# Altium schematic sheet Power.SchDoc(Power)
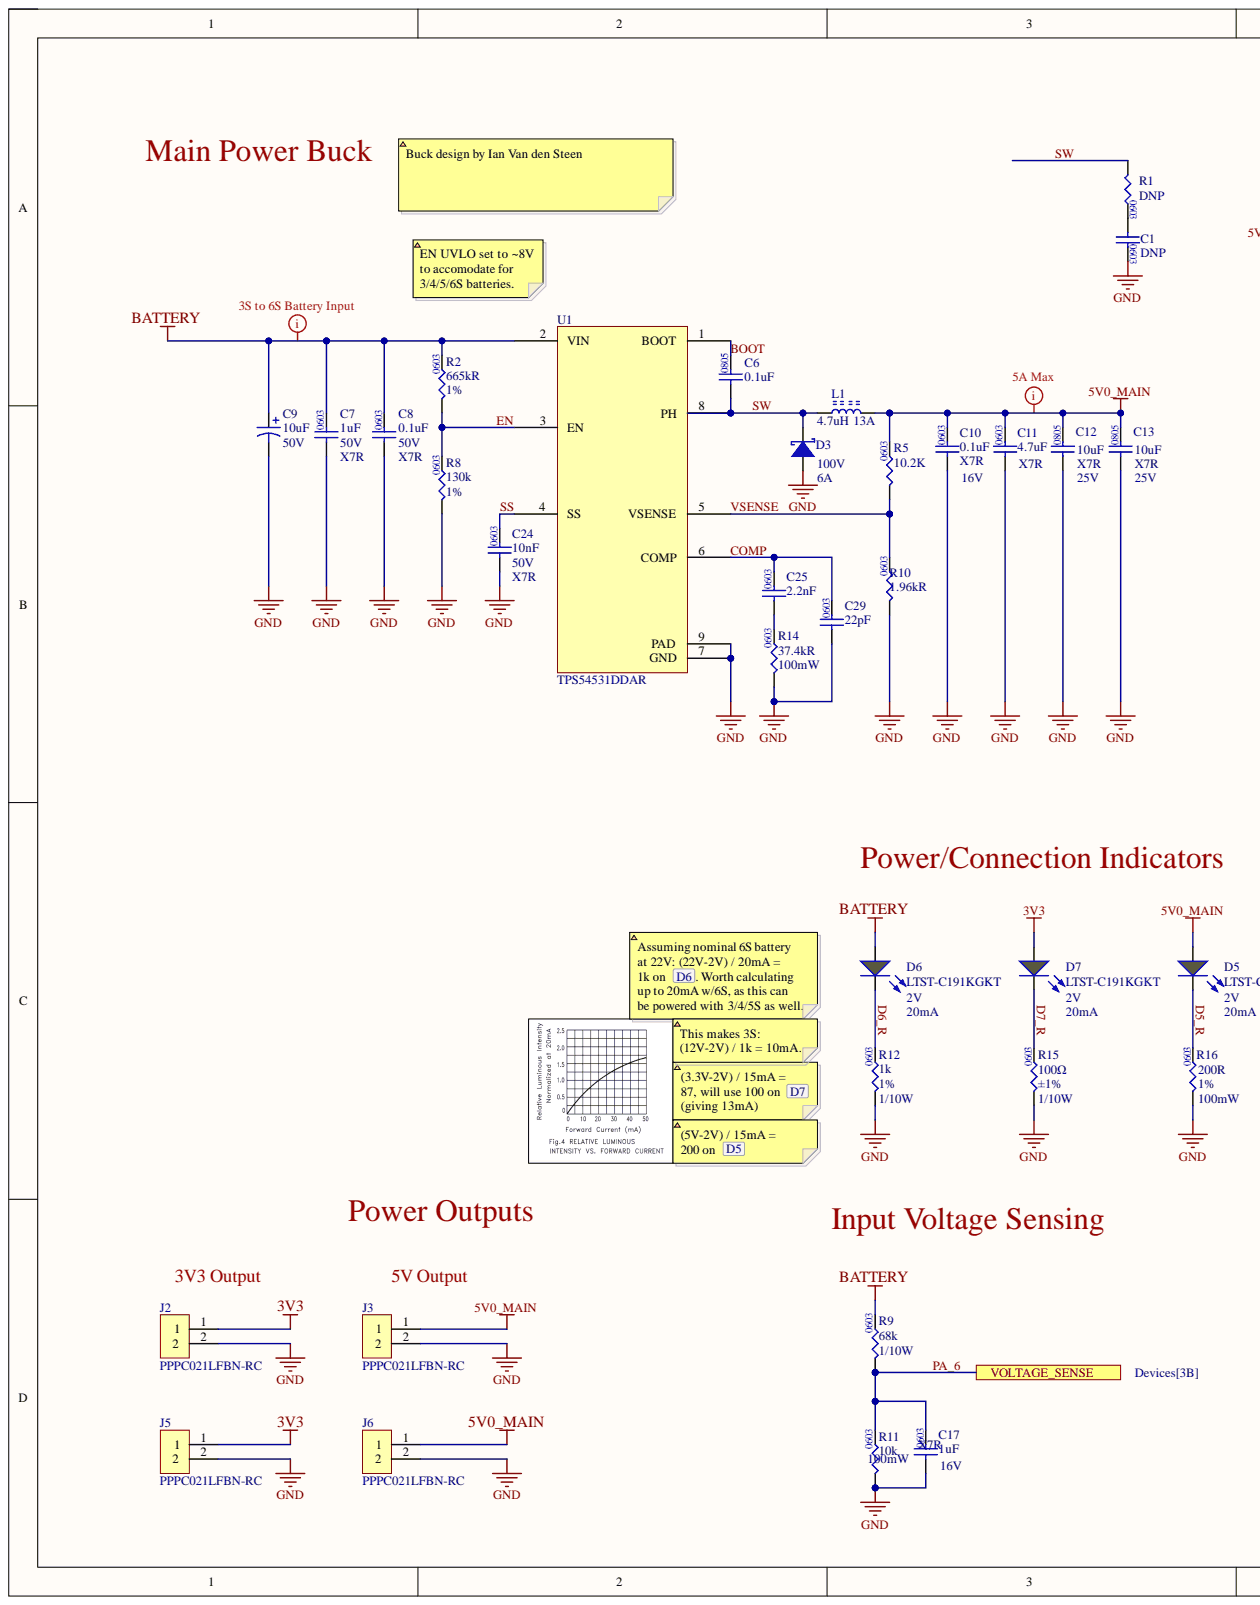
[diagram p0: Power.SchDoc, left]
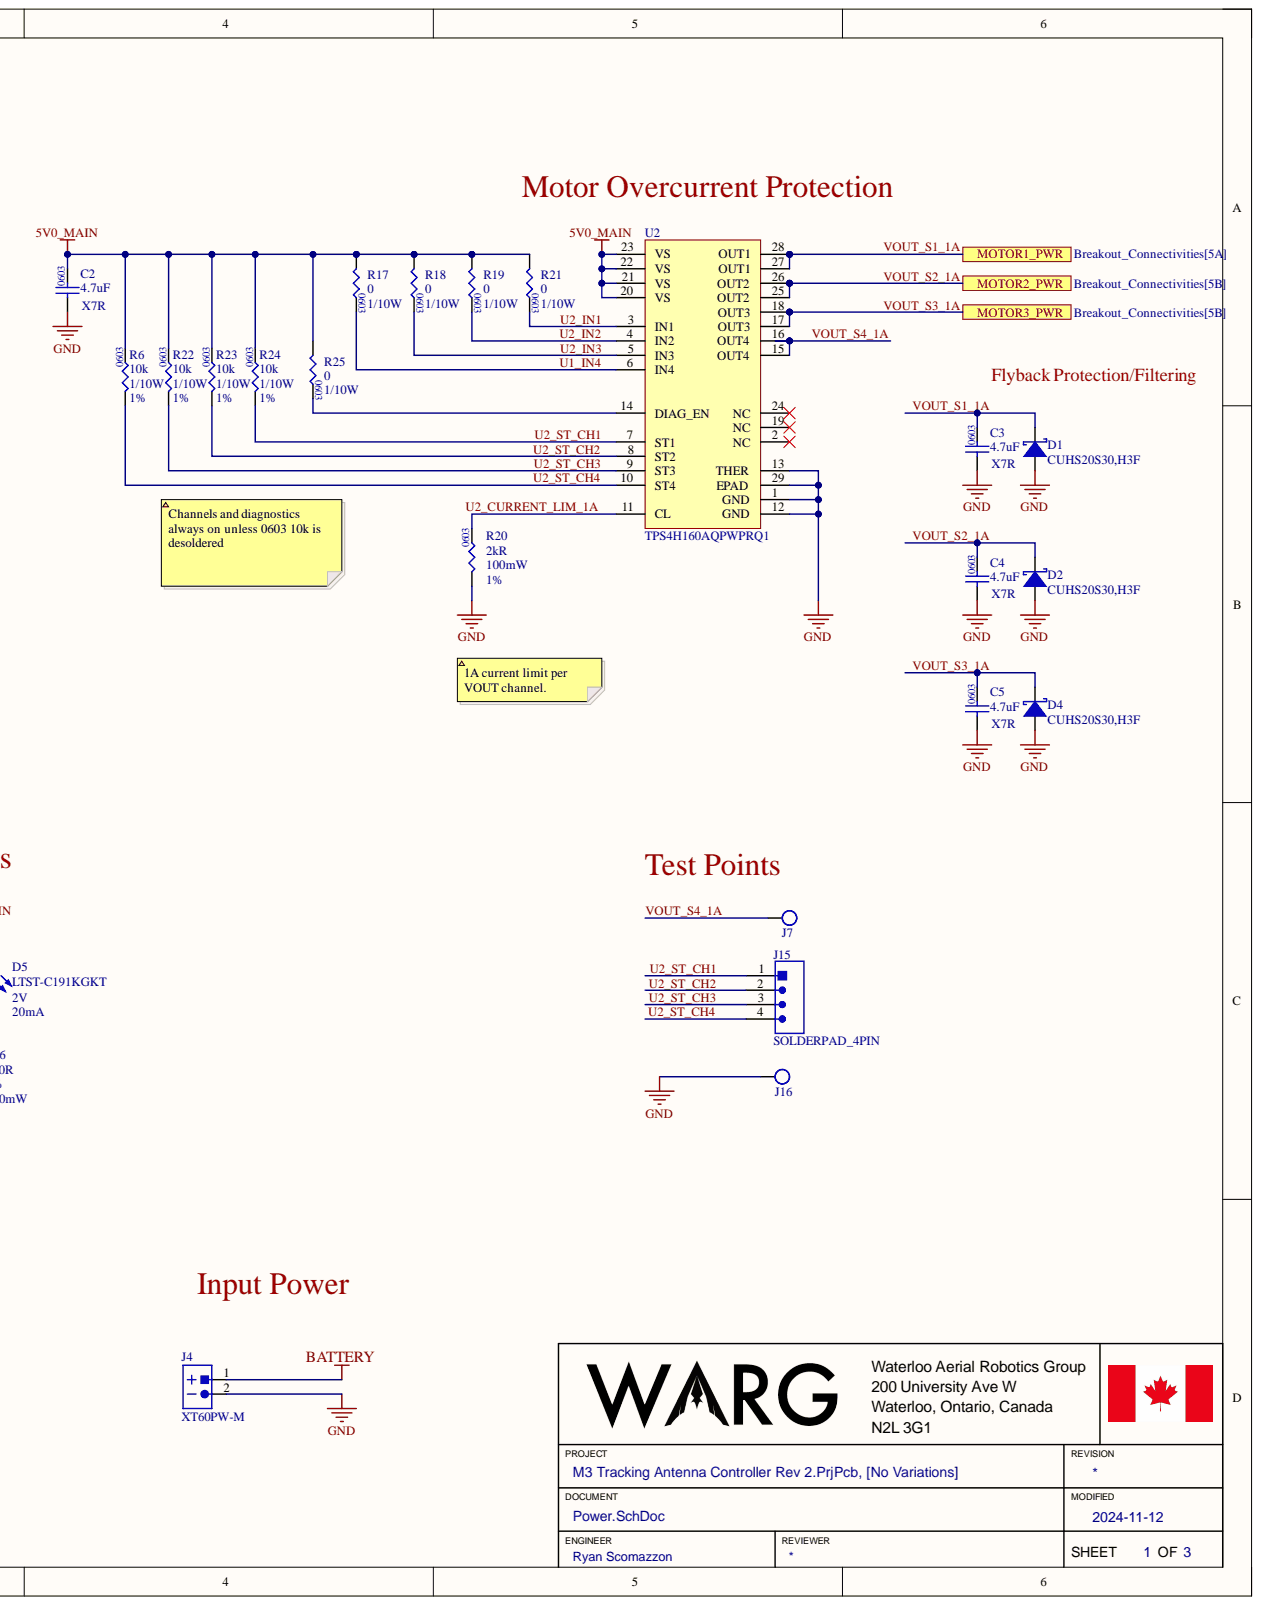
[diagram p1: Power.SchDoc, right]

## Parts
C1  value DNP  [.CAP_0603-Footprint-1]  (hidden comment: .CAP_0603_DNP)
C2  value 4.7uF  Case/Package: 0603  Temperature Characteristics Code: X7R  [.CAP_0603-Footprint-1]  (hidden comment: GRM188Z71C475KE21J)
C3  value 4.7uF  Case/Package: 0603  Temperature Characteristics Code: X7R  [.CAP_0603-Footprint-1]  (hidden comment: GRM188Z71C475KE21J)
C4  value 4.7uF  Case/Package: 0603  Temperature Characteristics Code: X7R  [.CAP_0603-Footprint-1]  (hidden comment: GRM188Z71C475KE21J)
C5  value 4.7uF  Case/Package: 0603  Temperature Characteristics Code: X7R  [.CAP_0603-Footprint-1]  (hidden comment: GRM188Z71C475KE21J)
C6  value 0.1uF  Case/Package: 0805  [.CAP_0805-Footprint-1]  (hidden comment: GCE21BR71H104KA01L)
C7  Capacitance: 1uF  Package Size: 0603  Temperature Coefficient: X7R  Voltage Rating: 50V  [.CAP_0603-Footprint-1]  (hidden comment: GMC10X7R105K50NT)
C8  value 0.1uF  Case Code (Imperial): 0603  Dielectric: X7R  Voltage: 50V  [.CAP_0603-Footprint-1]  (hidden comment: GCM188R71H104KA57D)
C9  value 10uF  Capacitance: 10uF  Voltage: 50V  [865080642006-Footprint-1]  (hidden comment: 865080642006)
C10  value 0.1uF  Package: 0603  Temperature Coefficient: X7R  Voltage: 16V  [.CAP_0603-Footprint-1]  (hidden comment: GCM188R71C104KA37D)
C11  value 4.7uF  Case/Package: 0603  Temperature Characteristics Code: X7R  [.CAP_0603-Footprint-1]  (hidden comment: GRM188Z71C475KE21J)
C12  Capacitance: 10uF  Temperature Coefficient: X7R  Voltage Rating: 25V  [.CAP_0805-Footprint-1]  (hidden comment: GMC21X7R106K25NT)
C13  Capacitance: 10uF  Temperature Coefficient: X7R  Voltage Rating: 25V  [.CAP_0805-Footprint-1]  (hidden comment: GMC21X7R106K25NT)
C17  value 1uF  Capacitance: 1uF  Package: 0603  Temperature Coefficient: X7R  Voltage: 16V  [.CAP_0603-Footprint-1]  (hidden comment: GCM188R71C105KA64J)
C24  Capacitance: 10nF  Dielectric: X7R  Package: 0603  Voltage: 50V  [.CAP_0603-Footprint-1]  (hidden comment: GCM188R71H103KA37D)
C25  value 2.2nF  Case/Package: 0603  [.CAP_0603-Footprint-1]  (hidden comment: GRM1885C1H222JA01D)
C29  value 22pF  Package: 0603  [.CAP_0603-Footprint-1]  (hidden comment: CC0603JRNPO9BN220)
D1  CUHS20S30,H3F  [CUHS20S30,H3F-Footprint-1]
D2  CUHS20S30,H3F  [CUHS20S30,H3F-Footprint-1]
D3  Current - Average Rectified (Io): 6A  Voltage - DC Reverse (Vr) (Max): 100V  [PMEG100V060ELPEZ-Footprint-1]  (hidden comment: PMEG100V060ELPEZ)
D4  CUHS20S30,H3F  [CUHS20S30,H3F-Footprint-1]
D5  LTST-C191KGKT  Forward Current: 20mA  Forward Voltage: 2V  [.LED_0603-Footprint-1]
D6  LTST-C191KGKT  Forward Current: 20mA  Forward Voltage: 2V  [.LED_0603-Footprint-1]
D7  LTST-C191KGKT  Forward Current: 20mA  Forward Voltage: 2V  [.LED_0603-Footprint-1]
J2  PPPC021LFBN-RC  [PPPC021LFBN-RC-Footprint-1]
J3  PPPC021LFBN-RC  [PPPC021LFBN-RC-Footprint-1]
J4  XT60PW-M  [XT60PW-M-Footprint-1]
J5  PPPC021LFBN-RC  [PPPC021LFBN-RC-Footprint-1]
J6  PPPC021LFBN-RC  [PPPC021LFBN-RC-Footprint-1]
J7  BLDC_SOLDER_PAD  [BLDC_SOLDER_PAD-Footprint-1]  (value not drawn)
J15  SOLDERPAD_4PIN  [SOLDERPAD_4PIN-Footprint-1]
J16  BLDC_SOLDER_PAD  [BLDC_SOLDER_PAD-Footprint-1]  (value not drawn)
L1  value 4.7uH  Current Rating: 13A  [7443330470-Footprint-1]  (hidden comment: 7443330470)
R1  value DNP  [.RES_0603-Footprint-1]  (hidden comment: .RES_0603_DNP)
R2  value 665kR  Case/Package: 0603  Tolerance: 1%  [.RES_0603-Footprint-1]  (hidden comment: RC0603FR-07665KL)
R5  value 10.2K  [.RES_0603-Footprint-1]  (hidden comment: RC0603FR-0710K2L)
R6  value 10k  Package: 0603  Power: 1/10W  Resistance: 10k  Tolerance: 1%  [.RES_0603-Footprint-1]  (hidden comment: RC0603FR-0710KL)
R8  value 130k  Case/Package: 0603  Tolerance: 1%  [.RES_0603-Footprint-1]  (hidden comment: RC0603FR-07130KL)
R9  value 68k  Package: 0603  Power: 1/10W  Resistance: 68k  [.RES_0603-Footprint-1]  (hidden comment: RC0603FR-0768KL)
R10  value 1.96kR  Case/Package: 0603  [.RES_0603-Footprint-1]  (hidden comment: RC0603FR-071K96L)
R11  Package: 0603  Power: 100mW  Resistance: 10k  [.RES_0603-Footprint-1]  (hidden comment: AC0603FR-1310KL)
R12  value 1k  Package: 0603  Power: 1/10W  Tolerance: 1%  [.RES_0603-Footprint-1]  (hidden comment: RC0603FR-071KL)
R14  value 37.4kR  Case/Package: 0603  Power: 100mW  [.RES_0603-Footprint-1]  (hidden comment: RC0603FR-0737K4L)
R15  Package: 0603  Power: 1/10W  Resistance: 100Ω  Tolerance: ±1%  [.RES_0603-Footprint-1]  (hidden comment: RC0603FR-07100RL)
R16  Case/Package: 0603  Power Rating: 100mW  Resistance: 200R  Tolerance: 1%  [.RES_0603-Footprint-1]  (hidden comment: RC0603FR-07200RL)
R17  value 0  Package: 0603  Power: 1/10W  [.RES_0603-Footprint-1]  (hidden comment: RC0603JR-070RL)
R18  value 0  Package: 0603  Power: 1/10W  [.RES_0603-Footprint-1]  (hidden comment: RC0603JR-070RL)
R19  value 0  Package: 0603  Power: 1/10W  [.RES_0603-Footprint-1]  (hidden comment: RC0603JR-070RL)
R20  value 2kR  Case/Package: 0603  Power: 100mW  Tolerance: 1%  [.RES_0603-Footprint-1]  (hidden comment: RC0603FR-072KL)
R21  value 0  Package: 0603  Power: 1/10W  [.RES_0603-Footprint-1]  (hidden comment: RC0603JR-070RL)
R22  value 10k  Package: 0603  Power: 1/10W  Resistance: 10k  Tolerance: 1%  [.RES_0603-Footprint-1]  (hidden comment: RC0603FR-0710KL)
R23  value 10k  Package: 0603  Power: 1/10W  Resistance: 10k  Tolerance: 1%  [.RES_0603-Footprint-1]  (hidden comment: RC0603FR-0710KL)
R24  value 10k  Package: 0603  Power: 1/10W  Resistance: 10k  Tolerance: 1%  [.RES_0603-Footprint-1]  (hidden comment: RC0603FR-0710KL)
R25  value 0  Package: 0603  Power: 1/10W  [.RES_0603-Footprint-1]  (hidden comment: RC0603JR-070RL)
U1  TPS54531DDAR  [TPS54531DDAR]
U2  TPS4H160AQPWPRQ1  [TPS4H160AQPWPRQ1-Footprint-1]

## Ports
MOTOR1_PWR, MOTOR2_PWR, MOTOR3_PWR, VOLTAGE_SENSE

## Nets
3V3: D7.1 J2.1 J5.1
5V0_MAIN: C2.2 C10.2 C11.2 C12.2 C13.2 D5.1 J3.1 J6.1 L1.2 R5.2 R6.2 R17.1 R18.1 R19.1 R21.1 R22.2 R23.2 R24.2 R25.1 U2.20(VS) U2.21(VS) U2.22(VS) U2.23(VS)
BATTERY: C7.2 C8.2 C9.1 D6.1 J4.1 R2.2 R9.2 U1.2(VIN)
GND: C1.2 C2.1 C3.1 C4.1 C5.1 C7.1 C8.1 C9.2 C10.1 C11.1 C12.1 C13.1 C17.1 C24.1 C29.1 D1.1 D2.1 D3.1 D4.1 J2.2 J3.2 J4.2 J5.2 J6.2 J16.1 R8.1 R10.1 R11.1 R12.1 R14.1 R15.1 R16.1 R20.1 U1.7(GND) U1.9(PAD) U2.1(GND) U2.12(GND) U2.13(THER) U2.29(EPAD)
BOOT: C6.2 U1.1(BOOT)
COMP: C25.2 C29.2 U1.6(COMP)
D5_R: D5.2 R16.2
D6_R: D6.2 R12.2
D7_R: D7.2 R15.2
EN: R2.1 R8.2 U1.3(EN)
PA_6: C17.2 R9.1 R11.2 ; port VOLTAGE_SENSE
SS: C24.2 U1.4(SS)
SW: C6.1 D3.2 L1.1 R1.1 U1.8(PH)
U1_IN4: R17.2 U2.6(IN4)
U2_CURRENT_LIM_1A: R20.2 U2.11(CL)
U2_IN1: R21.2 U2.3(IN1)
U2_IN2: R19.2 U2.4(IN2)
U2_IN3: R18.2 U2.5(IN3)
U2_ST_CH1: J15.1 R24.1 U2.7(ST1)
U2_ST_CH2: J15.2 R23.1 U2.8(ST2)
U2_ST_CH3: J15.3 R22.1 U2.9(ST3)
U2_ST_CH4: J15.4 R6.1 U2.10(ST4)
VOUT_S1_1A: C3.2 D1.2 U2.27(OUT1) U2.28(OUT1) ; port MOTOR1_PWR
VOUT_S2_1A: C4.2 D2.2 U2.25(OUT2) U2.26(OUT2) ; port MOTOR2_PWR
VOUT_S3_1A: C5.2 D4.2 U2.17(OUT3) U2.18(OUT3) ; port MOTOR3_PWR
VOUT_S4_1A: J7.1 U2.15(OUT4) U2.16(OUT4)
VSENSE: R5.1 R10.2 U1.5(VSENSE)
NetC1_1: C1.1 R1.2
NetC25_1: C25.1 R14.2
NetR25_2: R25.2 U2.14(DIAG_EN)

Not connected: U2 2(NC) 19(NC) 24(NC)

## Library data of the parts
C1 = CMP-001-00022-4: DNP 0603 Capacitor; Component Kind: Standard; Library Name: WARG
C2-C5 C11 = CMP-00441-1: CAP CER 4.7UF 16V X7R 0603; Capacitor Type: Ceramic; Component Kind: Standard; Mounting Technology: Surface Mount; Library Name: WARG
C6 = CMP-00075-2: CAP CER 0.1uF 50V X7R 0805; Tolerance: 10%; Component Kind: Standard; Voltage Rating: 50V; Library Name: WARG
C7 = CMP-00272-1: CAP0603 X7R 1UF 10% 50V; Component Kind: Standard; Tolerance: 10%; Library Name: WARG
C8 = CMP-00047-4: CAP CER 0.1uF 50V X7R 0603; Capacitance: 100nF; Tolerance: 10%; Component Kind: Standard; Mount: Surface Mount; Library Name: WARG
C9 = CMP-001-00008-5: 10 µF 50 V Aluminum Electrolytic Capacitors Radial; Component Kind: Standard; Library Name: WARG
C10 = CMP-001-00003-9: CAP CER 100nF 16V X7R 0603; Capacitance: 100nF; Component Kind: Standard; Library Name: WARG
C12 C13 = CMP-00241-5: CAP CER 10UF 25V X7R 0805; Tolerance: 10%; Component Kind: Standard; Library Name: WARG
C17 = CMP-001-00007-7: CAP CER 1uF 16V X7R 0603; Component Kind: Standard; Library Name: WARG
C24 = CMP-00050-3: CAP CER 0.01UF 50V X7R 0603; Component Kind: Standard; Library Name: WARG
C25 = CMP-001-00082-1: CAP CER 2200PF 50V C0G/NP0 0603; Capacitor Type: Ceramic; Multilayer: Yes; Temperature Characteristics Code: C0G; Tolerance  (fill in as +-): 5%; Component Kind: Standard; Dielectric Material: Ceramic; Height: 0.8mm; JESD-609 Code: e3; Length: 1.6mm; Max Operating Temperature: 125°C; Min Operating Temperature: -55°C; Mounting Technology: Surface Mount; Package Shape: Rectangular; Package Style: SMTMeter; Packaging: Tape and Reel; Pins: 2; Rated DC Voltage (URdc): 50V; Reach SVHC Compliant: Yes; RoHS Compliant: Yes; Terminal Finish: Nickel; Termination: Wraparound; Tolerance (fill in as +-): 5%; Width: 0.8mm; Library Name: WARG
C29 = CMP-00246-3: CAP CER 22PF 50V C0G/NPO 0603; Temperature Coefficient: C0G,NP0; Tolerance: 5%; Component Kind: Standard; Voltage: 50V; Library Name: WARG
D1 D2 D4 = CMP-001-0008-2: DIODE SCHOTTKY 30V 2A US2H; Capacitance @ Vr, F: 390pF @ 0V, 1MHz; Current - Reverse Leakage @ Vr: 500 µA @ 30 V; Speed: Fast Recovery =< 500ns, > 200mA (Io); Voltage - Forward (Vf) @ If: 0.28 V @ 1 A; Component Kind: Standard; Current - Average Rectified (Io): 2 A; Technology: Schottky; Voltage - DC Reverse (Vr) (Max): 30 V; Library Name: WARG
D3 = CMP-00448-3: PMEG100V060ELPE - 100 V, 6 A low leakage current Schottky barrier rectifier; Current - Reverse Leakage @ Vr: 100 nA @ 100 V; Voltage - Forward (Vf) (Max) @ If: 840 mV @ 6 A; Component Kind: Standard; Mounting type: Surface Mount; Library Name: WARG
D5-D7 = CMP-001-00018-6: LED GREEN 2V 0603; Case/Package: 0603; Color: Green; Component Kind: Standard; Library Name: WARG
J2 J3 J5 J6 = CMP-002-00003-2: CONN HEADER 2X1 2.54MM FEMALE VERT TH; Manufacturer: Sullins Connector Solutions; Component Kind: Standard; Manufacturer P/N: PPPC021LFBN-RC; Pitch: 2.54mm; Supplier: Digikey; Supplier Part Number: S7035-ND; Library Name: WARG
J4 = CMP-00007-11: Board Mount XT60PW-M 2 Pin Male Connector; Manufacturer: TME; Component Kind: Standard; Library Name: WARG
J7 J16 = CMP-00052-1: Solder Pad for BLDC Motor Contorl Output; Component Kind: Standard; Library Name: WARG
J15 = CMP-00291-1: Solder Pad 4 Pin 2.54 mm pitch; Component Kind: Standard; Library Name: WARG
L1 = CMP-00450-3: FIXED IND 4.7UH 13A 9.9 MOHM SMD; Core Material: Ferrite; Inductor Type: GENERAL PURPOSE INDUCTOR; Component Kind: Standard; DC Resistance (DCR): 9.9mOhm; Library Name: WARG
R1 = CMP-009-00018-3: DNP 0603 Resistor; Component Kind: Standard; Library Name: WARG
R2 = CMP-00435-1: RES 665K OHM 1% 1/10W 0603; Working Voltage: 75V; Component Kind: Standard; Resistor Type: FIXED RESISTOR; Library Name: WARG
R5 = CMP-009-00043-1: RES 10.2K OHM 1% 1/10W 0603; Component Kind: Standard; Library Name: WARG
R6 R22-R24 = CMP-009-00006-5: RES 10K OHM 1% 1/10W 0603; Component Kind: Standard; Library Name: WARG
R8 = CMP-00114-2: RES 130K OHM 1% 1/10W 0603; Power Rating: 100mW; Voltage Rating: 75V; Component Kind: Standard; Library Name: WARG
R9 = CMP-00169-4: RES 68K OHM 1% 1/10W 0603; Component Kind: Standard; Tolerance: 1%; Library Name: WARG
R10 = CMP-00437-1: RES 1.96K OHM 1% 1/10W 0603; Working Voltage: 75V; Component Kind: Standard; Tolerance: 1%; Library Name: WARG
R11 = CMP-009-00054-3: RES 10K OHM 1% 1/10W 0603; Component Kind: Standard; Tolerance: 1%; Library Name: WARG
R12 = CMP-009-00012-4: RES 1k OHM 1% 1/10W 0603; Component Kind: Standard; Library Name: WARG
R14 = CMP-00433-1: RES 37.4K OHM 1% 1/10W 0603; Working Voltage: 75V; Component Kind: Standard; Resistor Type: FIXED RESISTOR; Tolerance: 1%; Library Name: WARG
R15 = CMP-00022-4: RES 100 OHM 1% 1/10W 0603; Component Kind: Standard; Library Name: WARG
R16 = CMP-00103-1: RES 200 OHM 1% 1/10W 0603; Voltage Rating: 50V; Component Kind: Standard; Library Name: WARG
R17-R19 R21 R25 = CMP-009-00017-4: RES 0 OHM 1% 1/10W 0603; Resistance: 0Ω; Component Kind: Standard; Tolerance: 1%; Library Name: WARG
R20 = CMP-00276-1: RES 2K OHM 1% 1/10W 0603; Temperature Coefficient: 100ppm/°C; Component Kind: Standard; Library Name: WARG
U1 = CMP-00425-01-5: IC REG BUCK ADJ 5A 8SOPWR; Max Supply Voltage: 28V; Min Input Voltage: 3.5V; Case/Package: HLSOP; Component Kind: Standard; Max Output current: 5A; Surface Mount: Yes; Library Name: WARG
U2 = CMP-00273-2-5: IC PWR SWTCH N-CHAN 1:1 28HTSSOP; Component Kind: Standard; Library Name: WARG
